# Altium schematic sheet 05_POWER.SchDoc
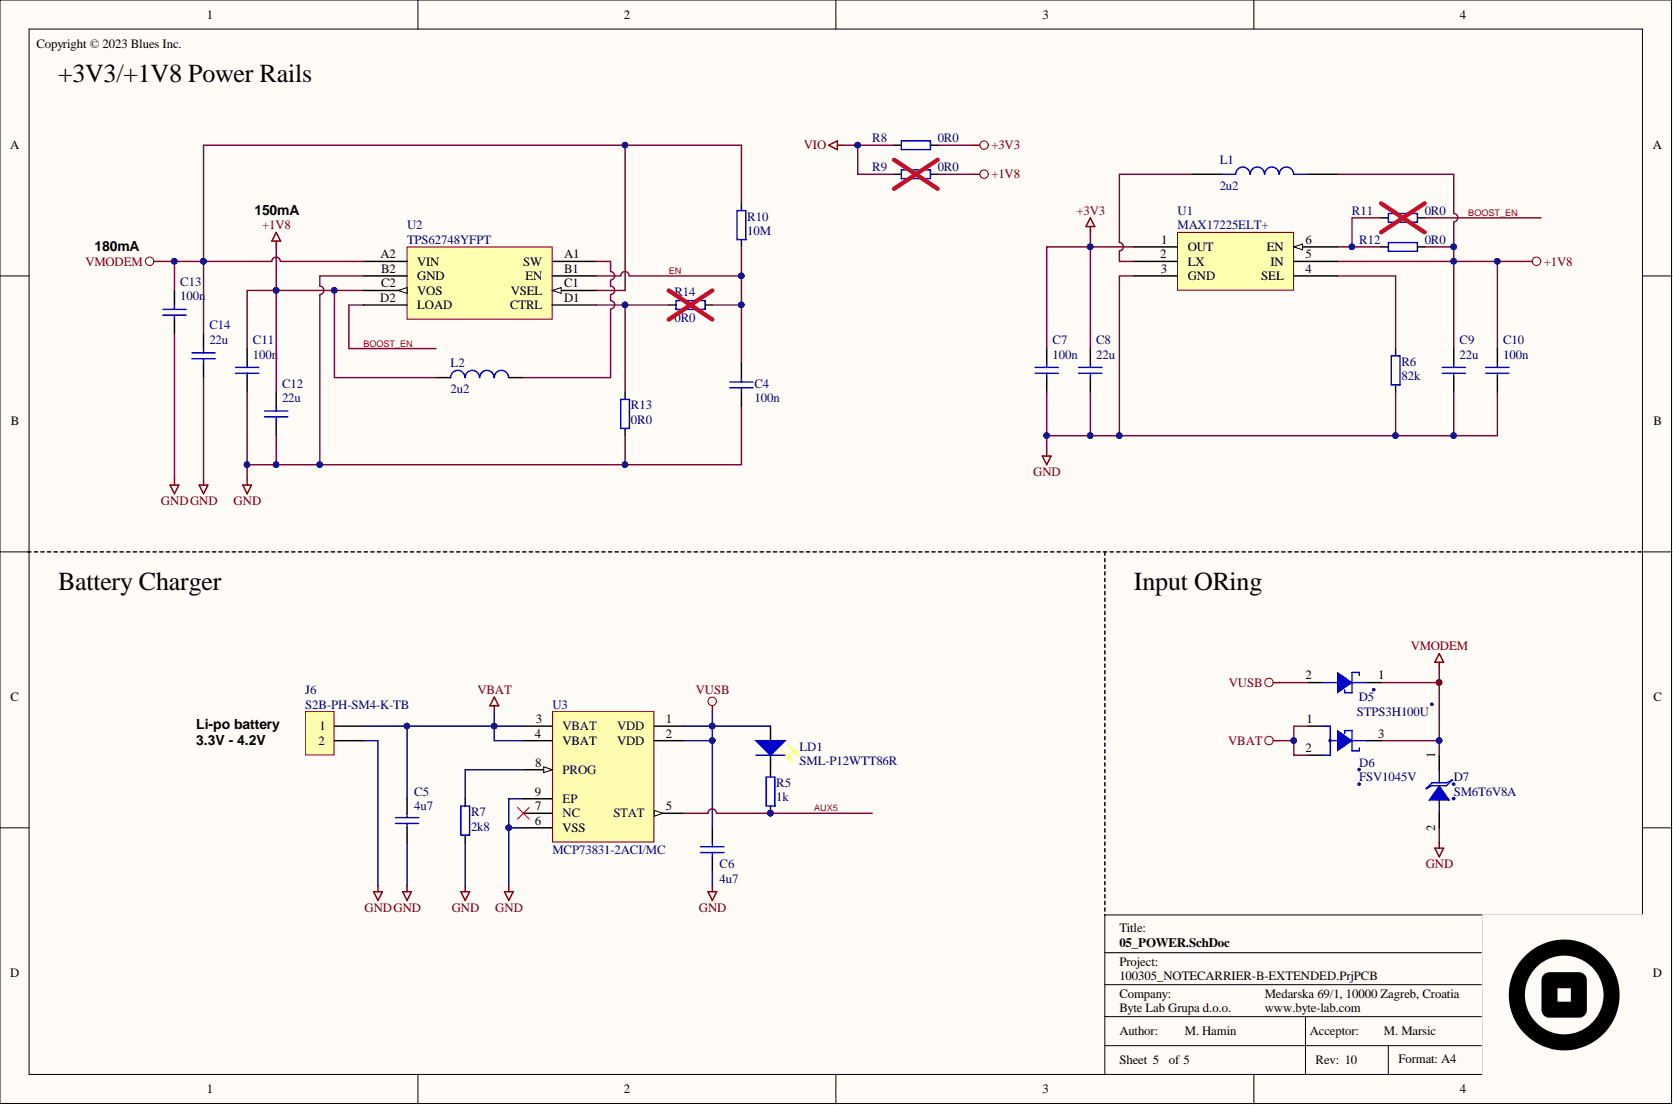
[diagram p0: 05_POWER.SchDoc]

## Parts
C4  100n  [C-0402]
C5  4u7  [C-0603]
C6  4u7  [C-0603]
C7  100n  [C-0402]
C8  22u  [C-0805]
C9  22u  [C-0805]
C10  100n  [C-0402]
C11  100n  [C-0402]
C12  22u  [C-0805]
C13  100n  [C-0402]
C14  22u  [C-0805]
D5  STPS3H100U  [SMB]
D6  FSV1045V  [TO277-3]
D7  SM6T6V8A  [SMB]
J6  S2B-PH-SM4-K-TB  [Conn S2B-PH-SM4]
L1  2u2  [IND_XFL4020-222MEC]
L2  2u2  [1285AS-H-2R2M=P2-INDC2016X100N]
LD1  SML-P12WTT86R  [LED-0402]
R5  1k  [R-0402]
R6  82k  [R-0402]
R7  2k8  [R-0402]
R8  0R0  [R-0402]
R9  0R0  [R-0402]
R10  10M  [R-0402]
R11  0R0  [R-0402]
R12  0R0  [R-0402]
R13  0R0  [R-0402]
R14  0R0  [R-0402]
U1  MAX17225ELT+  [MAX17225ELT+UDFN]
U2  TPS62748YFPT  [TPS62748YFPT-DSBGA4x2]
U3  MCP73831-2ACI/MC  [DFN50P200X300X90_HS-8N]

## Nets
+1V8: C9.2 C10.2 C11.2 C12.2 L1.2 L2.2 R9.2 R12.2 U1.5(IN) U2.C2(VOS)
+3V3: C7.2 C8.2 R8.2 U1.1(OUT)
GND: C4.1 C5.1 C6.1 C7.1 C8.1 C9.1 C10.1 C11.1 C12.1 C13.1 C14.1 D7.2 J6.2 J6.M R6.1 R7.1 R13.1 U1.3(GND) U2.B2(GND) U3.6(VSS) U3.9(EP)
VBAT: C5.2 D6.1 D6.2 J6.1 U3.3(VBAT) U3.4(VBAT)
VIO: R8.1 R9.1
VMODEM: C13.2 C14.2 D5.1 D6.3 D7.1 R10.2 U2.A2(VIN) U2.C1(VSEL)
VUSB: C6.2 D5.2 LD1.1 U3.1(VDD) U3.2(VDD)
AUX5: R5.1 U3.5(STAT)
BOOST_EN: R11.2 U2.D2(LOAD)
EN: C4.2 R10.1 R14.2 U2.B1(EN)
NetL1_1: L1.1 U1.2(LX)
NetL2_1: L2.1 U2.A1(SW)
NetLD1_2: LD1.2 R5.2
NetR6_2: R6.2 U1.4(SEL)
NetR7_2: R7.2 U3.8(PROG)
NetR11_1: R11.1 R12.1 U1.6(EN)
NetR13_2: R13.2 R14.1 U2.D1(CTRL)

Not connected: U3 7(NC)

## Library data of the parts
C4 C7 C10 C11 C13 = C0402-100n-16V-X7R: Capacitor ceramic 100n ±10% X7R 16V 0402; BL Part Number: 2000-908; Checked_By: Dodig; Drawn_By: Puskar; Component Kind: Standard; DateModified: 27-08-2013; Library Name: BL_Passive.SchLib; Manufacturer: N/A; Manufacturer Part Number: N/A; Simulation: CAP; Supplier 1: Digi-Key; Supplier Part Number 1: 490-3261-1-ND
C5 C6 = C0603-4u7-10V-X5R: Capacitor ceramic 4u7 ±10% X5R 10V 0603; BL Part Number: 2003-721; Drawn_By: Hamin; Component Kind: Standard; DateModified: 19-06-2023; Library Name: BL_Passive.SchLib; Manufacturer: N/A; Manufacturer Part Number: N/A
C8 C9 C12 C14 = C0805-22u-16V-X5R: Capacitor ceramic 22u ±10% X5R 16V 0805; BL Part Number: 2006-222; Checked_By: Hamin; Drawn_By: Fumic; Component Kind: Standard; DateModified: 28-07-2022; Library Name: BL_Passive.SchLib; Manufacturer: N/A; Manufacturer Part Number: N/A; Simulation: CAP
D5 = SCHOTTKY-STPS3H100U - 3A/100V: DIODO SCHOTTKY 3A 100V STPS3H100U SMB SMT; BL Part Number: 2007-711; Manufacturer: STMicroelectronics; Drawn_By: Blues Wireless; Component Kind: Standard; Library Name: n21-p2.SchLib; Manufacturer Part Number: STPS3H100U; Supplier 1: Digi-Key; Supplier Part Number 1: 497-10392-1-ND
D6 = SCHOTTKY - FSV1045V - 10A/45V: DIODO SCHOTTKY 10A 45V FSV1045V TO277-3 SMT; BL Part Number: 2007-712; Manufacturer: onsemi; Drawn_By: Blues Wireless; Component Kind: Standard; Library Name: n21-p2.SchLib; Manufacturer Part Number: FSV1045V; Supplier 1: Digi-Key; Supplier Part Number 1: FSV1045VCT-ND
D7 = TVS-UNI - SM6T6V8A - 6.8V/600W: DIODO TVS TRANSIL UNIDIR. 600W 6.8V SM6T6V8A SMB SMT; BL Part Number: 2007-453; Manufacturer: STMicroelectronics; Drawn_By: Blues Wireless; Component Kind: Standard; Library Name: n21-p2.SchLib; Manufacturer Part Number: SM6T6V8A; Supplier 1: Digi-Key; Supplier Part Number 1: 497-6501-1-ND
J6 = Conn S2B-PH-SM4-K-TB: 2.00mm pitch 2 pin side entry wire to board connector, SMD; BL Part Number: 2007-662; Manufacturer: JST; Manufacturer Part Number: S2B-PH-SM4-K-TB(LF)(SN); Drawn_By: Hamin; Component Kind: Standard; DateModified: 19-06-2023; Library Name: BL_Mechanical.SchLib; Supplier 1: Digi-Key
L1 = XFL4020-222MEC: Power Inductors - SMD 2.2Uh Shld 8A 23.5mOhms AECQ2; BL Part Number: 2007-483; Manufacturer: Coilcraft; Drawn_By: Blues Wireless; Component Kind: Standard; Library Name: n21-p2.SchLib; Manufacturer Part Number: XFL4020-222MEC; Supplier 1: Mouser; Supplier Part Number 1: 994-XFL4020-222MEC
L2 = 1285AS-H-2R2M=P2: FIXED IND 2.2UH 1.5A 204MOHM SMD; BL Part Number: 2007-482; Manufacturer: Murata Electronics; Drawn_By: Blues Wireless; Component Kind: Standard; Library Name: n21-p2.SchLib; Manufacturer Part Number: 1285AS-H-2R2M=P2; Supplier 1: Digi-Key; Supplier Part Number 1: 490-10600-1-ND
LD1 = LED_SML-P12WTT86R: LED YELLOW CLEAR 0402 SMD; BL Part Number: 2007-660; Manufacturer: ROHM Semiconductor; Drawn_By: Hamin; Component Kind: Standard; DateModified: 19-06-23; Library Name: BL_Active.SchLib; Manufacturer Part Number: SML-P12WTT86R
R5 = R0402-1k-1: Resistor 1k ±1% 0402; BL Part Number: 2000-694; Checked_By: Zvonc; Drawn_By: Dodig; Component Kind: Standard; DateModified: 17-02-2016; Library Name: BL_Passive.SchLib; Manufacturer: N/A; Manufacturer Part Number: N/A; Supplier 1: Digi-Key; Supplier Part Number 1: 311-1.00KLRCT-ND
R6 = R0402-82k-1: Resistor 82k ±1% 0402; BL Part Number: 2001-566; Manufacturer: Yageo; Manufacturer Part Number: RC0402FR-0782KL; Checked_By: Hamin; Drawn_By: Puskar; Component Kind: Standard; DateModified: 20-08-2014; Library Name: BL_Passive.SchLib; Supplier 1: Digi-key; Supplier Part Number 1: 311-82.0KLRCT-ND
R7 = R0402-2k8-1: Resistor 2k8 ±1% 0402; BL Part Number: 2007-661; Drawn_By: Hamin; Component Kind: Standard; DateModified: 19-06-2023; Library Name: BL_Passive.SchLib; Manufacturer: N/A; Manufacturer Part Number: N/A
R8 R9 R11-R14 = R0402-0R0-1: Resistor 0R ±1% 0402; BL Part Number: 2000-757; Checked_By: Dodig; Drawn_By: Puskar; Component Kind: Standard; DateModified: 27-08-2013; Library Name: BL_Passive.SchLib; Manufacturer: N/A; Manufacturer Part Number: N/A; Supplier 1: Digi-Key; Supplier Part Number 1: 311-0.0JRCT-ND
R10 = R0402-10M-1: Resistor 10M ±1% 0402; BL Part Number: 2002-832; Checked_By: Dodig; Drawn_By: Zvonc; Component Kind: Standard; DateModified: 24-05-2016; Library Name: BL_Passive.SchLib; Manufacturer: N/A; Manufacturer Part Number: N/A; Supplier 1: Digi-Key; Supplier Part Number 1: RC0402FR-0710ML
U1 = MAX17225ELT+: IC REG BOOST ADJ 1A NANO 6UDFN; BL Part Number: 2007-481; Manufacturer: Maxim; Drawn_By: Blues Wireless; Component Kind: Standard; Library Name: n21-p2.SchLib; Manufacturer Part Number: MAX17225ELT+; Supplier 1: Digi-Key; Supplier Part Number 1: MAX17225ELT+ -ND
U2 = TPS62748YFPT: IC REG BUCK PROG 300MA 8DSBGA; BL Part Number: 2007-480; Manufacturer: Texas Instruments; Drawn_By: Blues Wireless; Component Kind: Standard; Library Name: n21-p2.SchLib; Manufacturer Part Number: TPS62748YFPT; Supplier 1: Digi-Key; Supplier Part Number 1: 296-43000-1-ND
U3 = MCP73831-2ACI/MC: IC CONTROLLER LI-ION 4.2V 8DFN; BL Part Number: 2002-183; Manufacturer: Microchip; Checked_By: Dodig; Drawn_By: Zvonc; Component Kind: Standard; DateModified: 14-04-2015; Library Name: BL_Analog.SchLib; Manufacturer Part Number: MCP73831-2ACI/MC; Supplier 1: Digi-Key; Supplier Part Number 1: MCP73831-2ACI/MC-ND
